# Altium schematic sheet CY-Remote-F1_3.SchDoc
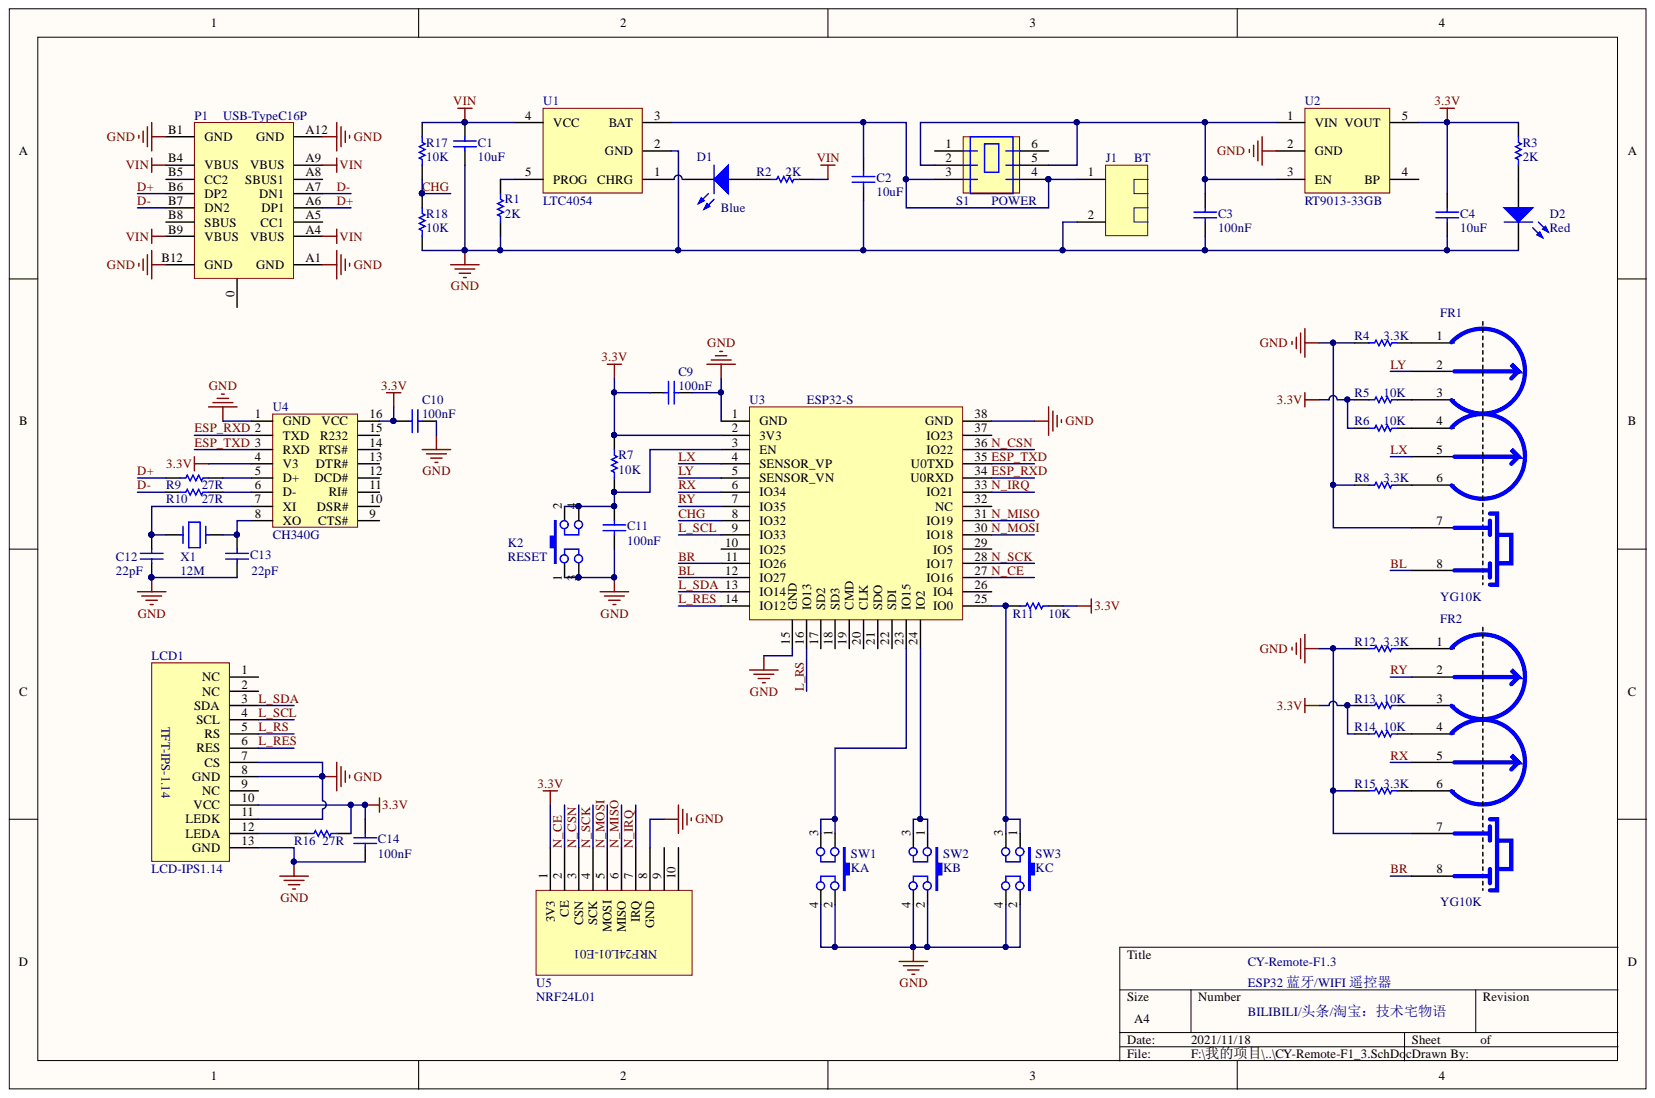
[diagram p0: CY-Remote-F1_3.SchDoc]

## Parts
C1  10uF  [C0603B]
C2  10uF  [C0603B]
C3  100nF  [C0603B]
C4  10uF  [C0603B]
C9  100nF  [C0603B]
C10  100nF  [C0603B]
C11  100nF  [C0603B]
C12  22pF  [C0603B]
C13  22pF  [C0603B]
C14  100nF  [C0603B]
D1  Blue  [LED0603-B]
D2  Red  [LED0603-B]
FR1  YG10K  [Potentiometer-alps joystick module]
FR2  YG10K  [Potentiometer-alps joystick module]
J1  BT  [MX1.25WS-2P]
K2  RESET  [DIP-6X6X5]
LCD1  LCD-IPS1.14  [LCD-IPS1_14_135x240-2]
P1  USB-TypeC16P  [USB-TypeC-16P1]
R1  2K  [RES0603]
R2  2K  [RES0603]
R3  2K  [RES0603]
R4  3.3K  [RES0603]
R5  10K  [RES0603]
R6  10K  [RES0603]
R7  10K  [RES0603]
R8  3.3K  [RES0603]
R9  27R  [RES0603]
R10  27R  [RES0603]
R11  10K  [RES0603]
R12  3.3K  [RES0603]
R13  10K  [RES0603]
R14  10K  [RES0603]
R15  3.3K  [RES0603]
R16  27R  [RES0603]
R17  10K  [RES0603]
R18  10K  [RES0603]
S1  POWER  [SW-SMD-9x3.5x3.5]
SW1  KA  [SW-4P-12x12-HAT-BLUE]
SW2  KB  [SW-4P-12x12-HAT-GREEN]
SW3  KC  [SW-4P-12x12-HAT-YELLOW]
U1  LTC4054  [SOT23-5]
U2  RT9013-33GB  [SOT23-5]
U3  ESP32-S  [ESP32-S-2]
U4  CH340G  [SOP16B]
U5  NRF24L01  [PE01-ML01SP2]
X1  12M  [49SMD]

## Nets
3.3V: C4.2 C9.1 C10.1 C14.2 LCD1.10(VCC) R3.2 R5.1 R6.1 R7.2 R11.2 R13.1 R14.1 R16.2 U2.5(VOUT) U3.2(3V3) U4.4(V3) U4.16(VCC) U5.1(3V3)
GND: C1.1 C2.1 C3.1 C4.1 C9.2 C10.2 C11.2 C12.1 C13.1 C14.1 D2.1 FR1.7 FR2.7 J1.2 K2.1 K2.3 LCD1.7(CS) LCD1.8(GND) LCD1.11(LEDK) LCD1.13(GND) P1.A1(GND) P1.A12(GND) P1.B1(GND) P1.B12(GND) R1.2 R4.1 R8.1 R12.1 R15.1 R18.1 SW1.2 SW1.4 SW2.2 SW2.4 SW3.2 SW3.4 U1.2(GND) U2.2(GND) U3.1(GND) U3.15(GND) U3.38(GND) U4.1(GND) U5.8(GND)
VIN: C1.2 P1.A4(VBUS) P1.A9(VBUS) P1.B4(VBUS) P1.B9(VBUS) R2.2 R17.2 U1.4(VCC)
BL: FR1.8 U3.12(IO27)
BR: FR2.8 U3.11(IO26)
CHG: R17.1 R18.2 U3.8(IO32)
D+: P1.A6(DP1) P1.B6(DP2) R9.1
D-: P1.A7(DN1) P1.B7(DN2) R10.1
ESP_RXD: U3.34(U0RXD) U4.2(TXD)
ESP_TXD: U3.35(U0TXD) U4.3(RXD)
L_RES: LCD1.6(RES) U3.14(IO12)
L_RS: LCD1.5(RS) U3.16(IO13)
L_SCL: LCD1.4(SCL) U3.9(IO33)
L_SDA: LCD1.3(SDA) U3.13(IO14)
LX: FR1.5 U3.4(SENSOR_VP)
LY: FR1.2 U3.5(SENSOR_VN)
N_CE: U3.27(IO16) U5.2(CE)
N_CSN: U3.36(IO22) U5.3(CSN)
N_IRQ: U3.33(IO21) U5.7(IRQ)
N_MISO: U3.31(IO19) U5.6(MISO)
N_MOSI: U3.30(IO18) U5.5(MOSI)
N_SCK: U3.28(IO17) U5.4(SCK)
RX: FR2.5 U3.6(IO34)
RY: FR2.2 U3.7(IO35)
NetC2_2: C2.2 J1.1 S1.3 S1.4 U1.3(BAT)
NetC3_2: C3.2 S1.2 S1.5 U2.1(VIN) U2.3(EN)
NetC11_1: C11.1 K2.2 K2.4 R7.1 U3.3(EN)
NetC12_2: C12.2 U4.7(XI) X1.1
NetC13_2: C13.2 U4.8(XO) X1.2
NetD1_1: D1.1 U1.1(CHRG)
NetD1_2: D1.2 R2.1
NetD2_2: D2.2 R3.1
NetFR1_1: FR1.1 R4.2
NetFR1_3: FR1.3 R5.2
NetFR1_4: FR1.4 R6.2
NetFR1_6: FR1.6 R8.2
NetFR2_1: FR2.1 R12.2
NetFR2_3: FR2.3 R13.2
NetFR2_4: FR2.4 R14.2
NetFR2_6: FR2.6 R15.2
NetLCD1_12: LCD1.12(LEDA) R16.1
NetR1_1: R1.1 U1.5(PROG)
NetR9_2: R9.2 U4.5(D+)
NetR10_2: R10.2 U4.6(D-)
NetR11_1: R11.1 SW3.1 SW3.3 U3.25(IO0)
NetSW1_1: SW1.1 SW1.3 U3.23(IO15)
NetSW2_1: SW2.1 SW2.3 U3.24(IO2)

Not connected: LCD1 1(NC) 2(NC) 9(NC); P1 0 A5(CC1) A8(SBUS1) B5(CC2) B8(SBUS); S1 1 6; U2 4(BP); U3 10(IO25) 17(SD2) 18(SD3) 19(CMD) 20(CLK) 21(SDO) 22(SDI) 26(IO4) 29(IO5) 32(NC) 37(IO23); U4 9(CTS#) 10(DSR#) 11(RI#) 12(DCD#) 13(DTR#) 14(RTS#) 15(R232); U5 9 10

## Library data of the parts
C1-C4 C9-C14 = CAP-Nonpolarity: CAP; Component Kind: Standard; Library Name: Johan.IntLib
D1 D2 = LED: Component Kind: Standard; Description: LED; Library Name: Johan.IntLib
FR1 FR2 = Potentiometer-YG10K: FJM10K; Component Kind: Standard; Library Name: Johan.IntLib
J1 = MX1.25-2P: Component Kind: Standard; Library Name: MX.IntLib
K2 SW1-SW3 = SW-4P: Switch; Component Kind: Standard; Library Name: Johan.IntLib
LCD1 = LCD-IPS1_14_135x240: Component Kind: Standard; Description: LCD-IPS1_14_135x240; Library Name: Johan.IntLib
P1 = CONN-USB-TypeC-16P: TypeC-16P; Component Kind: Standard; Library Name: Johan.IntLib
R1-R18 = RES: Component Kind: Standard; Description: RES; Library Name: Johan.IntLib
S1 = SWITCH-9x3.5x3.5: SMD6; Component Kind: Standard; Library Name: Johan.IntLib
U1 = IC-LTC4054: SOT23-5; Component Kind: Standard; Library Name: Johan.IntLib
U2 = IC-RT9193: SOT23-5; Component Kind: Standard; Library Name: Johan.IntLib
U3 = MODULAR-ESP32-S: Component Kind: Standard; Description: ESP32-S; Library Name: Johan.IntLib
U4 = IC-CH340G: USB2UART; Component Kind: Standard; Library Name: Johan.IntLib
U5 = MODULAR-NRF24L01-E01: E01-ML01SP2; Component Kind: Standard; Library Name: Johan.IntLib
X1 = XTAL-2P-1: Crystal; Component Kind: Standard; Library Name: Johan.IntLib
